# Altium schematic sheet [03] - Wi-Fi_Chip.SchDoc
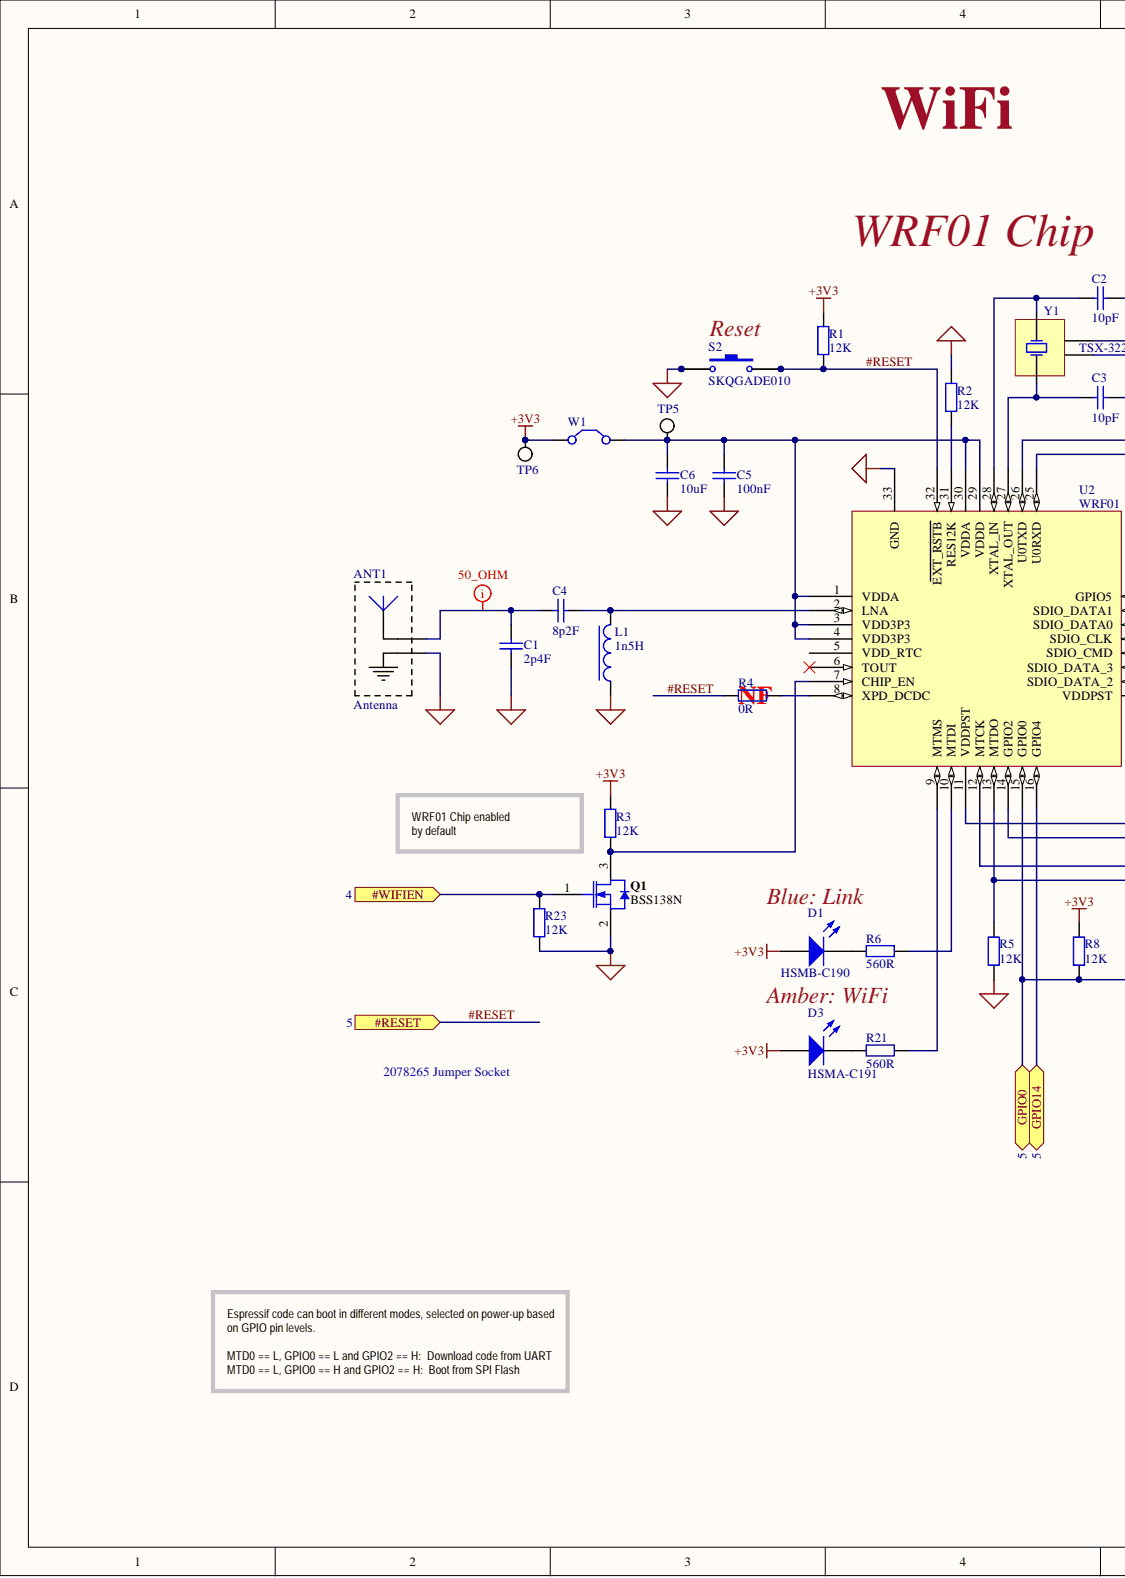
[diagram p0: [03] - Wi-Fi_Chip.SchDoc, left]
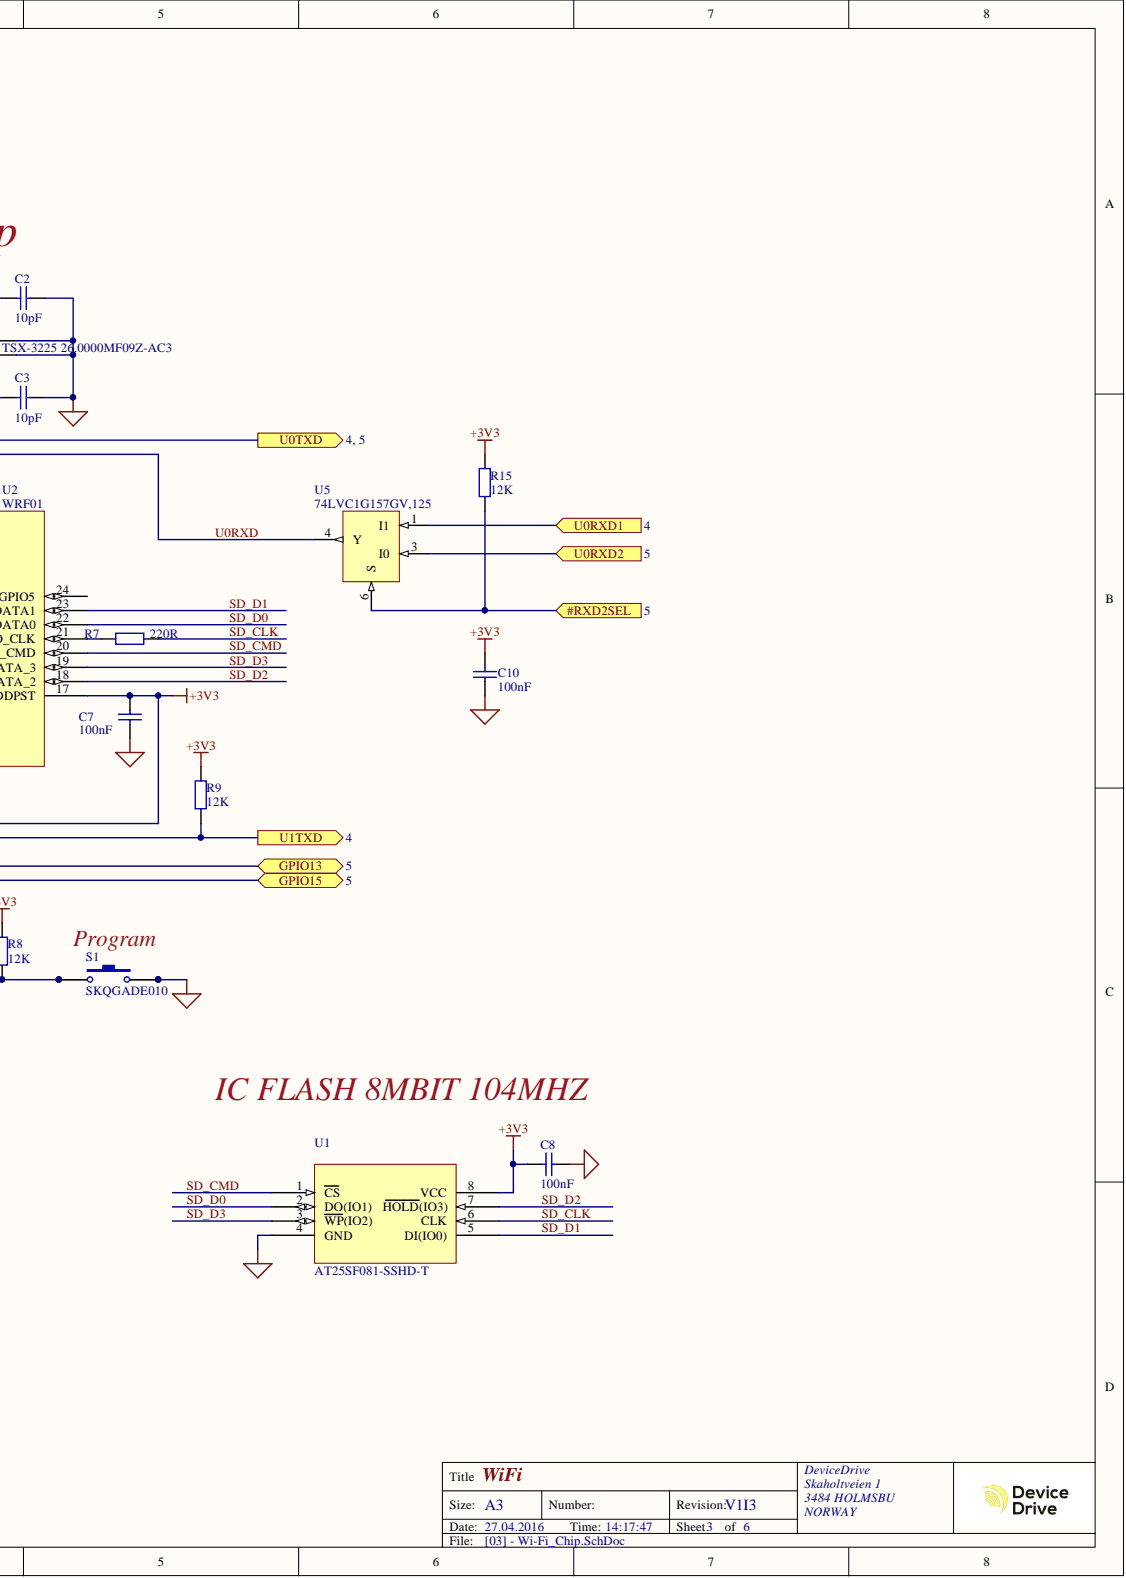
[diagram p1: [03] - Wi-Fi_Chip.SchDoc, right]

## Parts
ANT1  Antenna  [ANTENNA_WIFI_SMALL_INVERTED_F]
C1  2p4F  [C0402]
C2  10pF  [C0402]
C3  10pF  [C0402]
C4  8p2F  [C0402]
C5  100nF  [C0402]
C6  10uF  [C0603]
C7  100nF  [C0402]
C8  100nF  [C0402]
C10  100nF  [C0402]
D1  HSMB-C190  [LED_0603_BLUE]
D3  HSMA-C191  [LED_0603_AMBER]
L1  1n5H  [L0402]
Q1  BSS138N  [SOT-23]
R1  12K  [R0402]
R2  12K  [R0402]
R3  12K  [R0402]
R4  0R  [R0402]
R5  12K  [R0402]
R6  560R  [R0402]
R7  220R  [R0402]
R8  12K  [R0402]
R9  12K  [R0402]
R15  12K  [R0402]
R21  560R  [R0402]
R23  12K  [R0402]
S1  SKQGADE010  [SWITCH_ALPS_SKQGADE]
S2  SKQGADE010  [SWITCH_ALPS_SKQGADE]
TP5  Testpoint_1.5mm  [TESTPOINT_1.5MM]  (value not drawn)  (no BOM)
TP6  Testpoint_1.5mm  [TESTPOINT_1.5MM]  (value not drawn)  (no BOM)
U1  AT25SF081-SSHD-T  [8-SOIC]
U2  WRF01  [32-QFN]
U5  74LVC1G157GV,125  [SOT26A-6AN]
W1  Jumper  [MHDR1X2]  (value not drawn)
Y1  TSX-3225 26.0000MF09Z-AC3  [TSX-3225]

## Ports
#RESET, #RXD2SEL, #WIFIEN, GPIO0, GPIO13, GPIO14, GPIO15, U0RXD1, U0RXD2, U0TXD, U1TXD

## Nets
+3V3: C7.2 C8.2 C10.2 D1.2 D3.2 R1.1 R3.2 R8.2 R9.2 R15.2 TP6.1 U1.8(VCC) U2.11(VDDPST) U2.17(VDDPST) U5.5 W1.1
GND: ANT1.2 C1.1 C2.1 C3.1 C5.1 C6.1 C7.1 C8.1 C10.1 L1.1 Q1.2 R2.1 R5.1 R23.1 S1.3 S1.4 S2.1 S2.2 U1.4(GND) U2.33(GND) U5.2 Y1.2 Y1.4
#RESET: R1.2 R4.2 S2.3 S2.4 U2.32(EXT_RSTB) ; port #RESET
#RXD2SEL: R15.1 U5.6(S) ; port #RXD2SEL
#WIFIEN: Q1.1 R23.2 ; port #WIFIEN
GPIO0: R8.1 S1.1 S1.2 U2.15(GPIO0) ; port GPIO0
GPIO13: U2.12(MTCK) ; port GPIO13
GPIO14: U2.16(GPIO4) ; port GPIO14
GPIO15: R5.2 U2.13(MTDO) ; port GPIO15
SD_CLK: R7.1 U1.6(CLK)
SD_CMD: U1.1(CS) U2.20(SDIO_CMD)
SD_D0: U1.2(DO(IO1)) U2.22(SDIO_DATA0)
SD_D1: U1.5(DI(IO0)) U2.23(SDIO_DATA1)
SD_D2: U1.7(HOLD(IO3)) U2.18(SDIO_DATA_2)
SD_D3: U1.3(WP(IO2)) U2.19(SDIO_DATA_3)
U0RXD: U2.25(U0RXD) U5.4(Y)
U0RXD1: U5.1(I1) ; port U0RXD1
U0RXD2: U5.3(I0) ; port U0RXD2
U0TXD: U2.26(U0TXD) ; port U0TXD
U1TXD: R9.1 U2.14(GPIO2) ; port U1TXD
NetANT1_1: ANT1.1 C1.2 C4.1
NetC2_2: C2.2 U2.28(XTAL_IN) Y1.3
NetC3_2: C3.2 U2.27(XTAL_OUT) Y1.1
NetC4_2: C4.2 L1.2 U2.2(LNA)
NetC5_2: C5.2 C6.2 TP5.1 U2.1(VDDA) U2.3(VDD3P3) U2.4(VDD3P3) U2.29(VDDD) U2.30(VDDA) W1.2
NetD1_1: D1.1 R6.1
NetD3_1: D3.1 R21.1
NetQ1_3: Q1.3 R3.1 U2.7(CHIP_EN)
NetR2_2: R2.2 U2.31(RES12K)
NetR4_1: R4.1 U2.8(XPD_DCDC)
NetR6_2: R6.2 U2.10(MTDI)
NetR7_2: R7.2 U2.21(SDIO_CLK)
NetR21_2: R21.2 U2.9(MTMS)

Not connected: U2 5(VDD_RTC) 6(TOUT) 24(GPIO5)

## Library data of the parts
ANT1 = Antenna: Generic Antenna; Component Kind: Net Tie; LatestRevisionDate: 17-Jul-2002; LatestRevisionNote: Re-released for DXP Platform.; Library Name: IoTProject.SchLib; PackageReference: PIN1; Published: 24-Mar-1999; Publisher: Altium Limited
C1-C3 C5-C8 C10 = Cap: Capacitor; Value: 100pF; Component Kind: Standard; LatestRevisionDate: 17-Jul-2002; LatestRevisionNote: Re-released for DXP Platform.; Library Name: Miscellaneous Devices.IntLib; PackageDescription: Radial Cap, Thru-Hole; 2 Leads; 0.3 in Pin Spacing; PackageReference: RAD-0.3; Published: 8-Jun-2000; Signal Integrity: Cap; Simulation: CAP; Publisher: Altium Limited
C4 = Cap: Capacitor; PI-Artikkelnummer: 100572; Value: 100pF; Component Kind: Standard; LatestRevisionDate: 17-Jul-2002; LatestRevisionNote: Re-released for DXP Platform.; Library Name: Miscellaneous Devices.IntLib; PackageDescription: Radial Cap, Thru-Hole; 2 Leads; 0.3 in Pin Spacing; PackageReference: RAD-0.3; Published: 8-Jun-2000; Signal Integrity: Cap; Simulation: CAP; Publisher: Altium Limited
D1 = HSMB-C190: Blue Surface Mount Chip LED, Blue; LuminousIntensity: 1.6mcd (Minimum).; PCB_Layout: Complies with manufacturer's recommendation.; Component Kind: Standard; ComponentLink1Description: Manufacturer Link; ComponentLink1URL: http://www.agilent.com/; ComponentLink2Description: Datasheet; ComponentLink2URL: http://cp.literature.agilent.com/litweb/pdf/5988-5211EN.pdf; DatasheetDocument: 26-Feb-2002; LED_Colour: Blue; Library Name: Agilent Optoelectronic LED.IntLib; PackageDocument: 11-Mar-2002; PackageReference: 1.6X0.8X0.8; Published: 11-Dec-2002; Signal Integrity: LED; Simulation: Agl_HSMBC110; Publisher: Altium Limited
D3 = HSMA-C190: High Performance Chip LED, Amber; LuminousIntensity: 25mcd (Minimum).; PCB_Layout: Complies with manufacturer's recommendation.; Component Kind: Standard; ComponentLink1Description: Manufacturer Link; ComponentLink1URL: http://www.avagotech.com/; ComponentLink2Description: Datasheet; ComponentLink2URL: http://cp.literature.agilent.com/litweb/pdf/5988-5891EN.pdf; DatasheetVersion: 11-Mar-2002; LED_Colour: Amber; PackageDescription: SM LED; 2 Flat Leads; PackageReference: 1.6X0.8X0.8; PackageVersion: Mar-2002; Published: 11-Dec-2002; Signal Integrity: LED; Simulation: Agl_HSMAC191; Supplier 1: Farnell; Supplier Part Number 1: 8554480; Library Name: Avago Optoelectronic LED.IntLib; Publisher: Altium Limited
L1 = Inductor: Code_IEC: 1005; Value: 10mH; Code_JEITA: 0402; Component Kind: Standard; Description: Inductor; LatestRevisionDate: 29-May-2009; LatestRevisionNote: IPC-7351 Footprint Added.; Library Name: IoTProject_1.SCHLIB; PackageDescription: Chip Inductor; PackageReference: 0402-A; Published: 8-Jun-2000; Signal Integrity: Inductor; Simulation: INDUCTOR; Publisher: Altium Limited
Q1 = BSS138N: N-Channel SIPMOS Small-Signal-Transistor, 60 V VDS, 0.23 A ID, -55 to 150 degC, PG-SOT23, Reel, Green; Manufacturer: Infineon; Green: TRUE; ID (max): 0.23 A; IDpuls (max): 0.92 A; Ptot (max): 0.36 W; QG: 1 nC; RDS (on) (max): 3,500 mOhm; RDS (on) (max) (@4.5V): 6,000 mOhm; RthJA (max): 350 K/W; VDS (max): 60 V; VGS(th) (max): 1.4 V; VGS(th) (min): 0.6 V; Component Kind: Standard; ComponentLink1Description: Manufacturer URL; ComponentLink1URL: http://www.infineon.com/; ComponentLink2Description: Datasheet; ComponentLink2URL: http://www.infineon.com/dgdl/BSS138N_Rev2.86_.pdf?folderId=db3a3043156fd573011622e10b5c1f67&fileId=db3a304330f68606013104d944d53efb; ComponentLink3Description: Package Specification; ComponentLink3URL: http://www.infineon.com/dgdl/SOT23-Package_Overview.pdf?folderId=db3a30431936bc4b0119532290053c66&fileId=db3a30431936bc4b0119533468123c85; DatasheetVersion: Rev. 2.86; Halogen-free: TRUE; Library Name: IoTProject_1.SCHLIB; Mounting Technology: Surface Mount; PackageDescription: 3-Pin Small Outline Transistor Package, 2.9 x 1.3 mm Body, 0.95 mm Pitch; PackageReference: PG-SOT23; PackageVersion: Apr-08; Packing: Reel; PartNumber: BSS138N; Supplier 1: Newark; Supplier 2: Farnell; Supplier 3: Farnell; Supplier Part Number 1: 25M7814; Supplier Part Number 2: 1156434RL; Supplier Part Number 3: 1156434
R1-R9 R15 R21 R23 = Res2: Resistor; Value: 1K; Component Kind: Standard; LatestRevisionDate: 17-Jul-2002; LatestRevisionNote: Re-released for DXP Platform.; Library Name: Miscellaneous Devices.IntLib; PackageDescription: Axial Device, Thru-Hole; 2 Leads; 0.4 in Pin Spacing; PackageReference: AXIAL-0.4; Published: 8-Jun-2000; Signal Integrity: Res; Simulation: RESISTOR; Publisher: Altium Limited
S1 S2 = SW-PB: ALPS - SKQGADE010 - SWITCH, SPST, 0.05A, 12VDC, SMD; Component Kind: Standard; ComponentLink1Description: http://www.farnell.com/datasheets/1776657.pdf; ComponentLink1URL: http://www.farnell.com/datasheets/1776657.pdf; Library Name: IoTProject.SchLib; Manufacturer: ALPS; Manufacturer Part Number: SKQGADE010; Pricing 1: 5=1,95, 25=1,9, 50=1,85, 100=1,75, 500=1,7, 4000=0,88, 9000=0,863, 30000=0,846 (EUR); RoHS: YES; Supplier 1: Farnell; Supplier Part Number 1: 1656434
TP5 TP6 = Testpoint_1.5mm: Testpoint 1mm; Library Name: PI_Elmek.SCHLIB
U1 = W25Q16BV: IC FLASH 4MBIT 104MHZ 8SOIC; Manufacturer: Adesto Technologies; Manufacturer Part Number: AT25SF041-SSHD-T; Interface: SPI Serial; Memory Size: 4M (512K x 8); Voltage - Supply: 2.5 V ~ 3.6 V; Category: Integrated Circuits (ICs); Component Kind: Standard; ComponentLink1Description: http://www.adestotech.com/wp-content/uploads/DS-AT25SF041_044.pdf; ComponentLink1URL: http://www.adestotech.com/wp-content/uploads/DS-AT25SF041_044.pdf; Format - Memory: FLASH; Library Name: IoTProject.SchLib; Memory Type: FLASH; Operating Temperature: -40°C ~ 85°C; Package / Case: 8-SOIC (0.154", 3.90mm Width); Packaging: Cut Tape (CT); Pricing 1: 1=0.3, 10=0.29, 25=0.2692, 50=0.2678, 100=0.2379, 250=0.23468, 500=0.23126, 1000=0.22502 (EUR); RoHS: RoHS Compliant; Speed: 104MHz; Stock 1: 19241; Supplier 1: Digi-Key; Supplier Device Package: 8-SOIC; Supplier Part Number 1: 1265-1131-1-ND
U2 = ESP8266EX: Smart Connectivity Platform (ESCP); PI-Artikkelnummer: 103145; Component Kind: Standard; Library Name: IoTProject.SchLib
U5 = 74LVC16157: IC MULTIPLEXER 2INPUT 6TSOP; Manufacturer: NXP Semiconductors; Circuit: 1 x 2:1; Current - Output High, Low: 32mA, 32mA; Independent Circuits: 1; Voltage - Supply: 1.65 V ~ 5.5 V; Voltage Supply Source: Single Supply; Category: Integrated Circuits (ICs); Component Kind: Standard; ComponentLink1Description: http://www.nxp.com/documents/data_sheet/74LVC1G157.pdf; ComponentLink1URL: http://www.nxp.com/documents/data_sheet/74LVC1G157.pdf; Library Name: IoTProject_1.SCHLIB; Manufacturer Part Number: 74LVC1G157GV,125; Mounting Type: Surface Mount; Operating Temperature: -40°C ~ 125°C; Package / Case: SC-74, SOT-457; Packaging: Cut Tape (CT); Pricing 1: 1=0.36, 10=0.261, 25=0.2028, 100=0.1538, 250=0.10876, 500=0.08706, 1000=0.06677 (EUR); RoHS: RoHS Compliant; Stock 1: 21471; Supplier 1: Digi-Key; Supplier Device Package: 6-TSOP; Supplier Part Number 1: 568-3007-1-ND; Type: Multiplexer
W1 = Jumper: Jumper Wire; Component Kind: Standard; LatestRevisionDate: 17-Jul-2002; LatestRevisionNote: Re-released for DXP Platform.; Library Name: Miscellaneous Devices.IntLib; PackageDescription: Radial Cap, Thru-Hole; 2 Leads; 0.2 in Pin Spacing; PackageReference: RAD-0.2; Published: 24-Mar-1999; Publisher: Altium Limited
Y1 = XTAL: Crystals 26MHz 10ppm 9pF -20C +75C; Brand: Epson Timing; Case Height: 0.6 mm; Case Length: 3.2 mm; Case Width: 2.5 mm; ESR: 40 Ohms; Category: Crystals; Component Kind: Standard; ComponentLink1Description: http://www.mouser.com/ds/2/137/1721499-465440.pdf; ComponentLink1URL: http://www.mouser.com/ds/2/137/1721499-465440.pdf; Dimensions: 2.5 mm W x 3.2 mm L x 0.6 mm H; Frequency: 26 MHz; Frequency Stability: 10 PPM; Library Name: IoTProject_1.SCHLIB; Load Capacitance: 9 pF; Manufacturer: Epson Timing; Manufacturer Part Number: TSX-3225 26.0000MF09Z-AC3; Minimum Order Quantity: 1; Mounting Style: SMD/SMT; Operating Temperature Range: - 20 C to + 75 C; Package / Case: 3.2 mm x 2.5 mm; Packaging: Reel; Pricing 1: 1=0.960, 10=0.800, 100=0.720, 250=0.720, 500=0.560, 1000=0.512, 2500=0.478, 5000=0.462, 10000=0.446, 25000=0.389 (AUD); Reeling: True; RoHS: RoHS Compliant; RoHS - Mouser: Y; Series: FA-238V/FA-238/TSX-3225; Simulation: XTAL; Standard Pack Qty: 250; Stock 1: 6956; Supplier 1: Mouser; Supplier Part Number 1: 732-TX325-26F09Z-AC3; Termination Style: SMD/SMT; Tolerance: 10 PPM; Type: MHz Range Crystal Unit
